# Altium schematic sheet Main.SchDoc
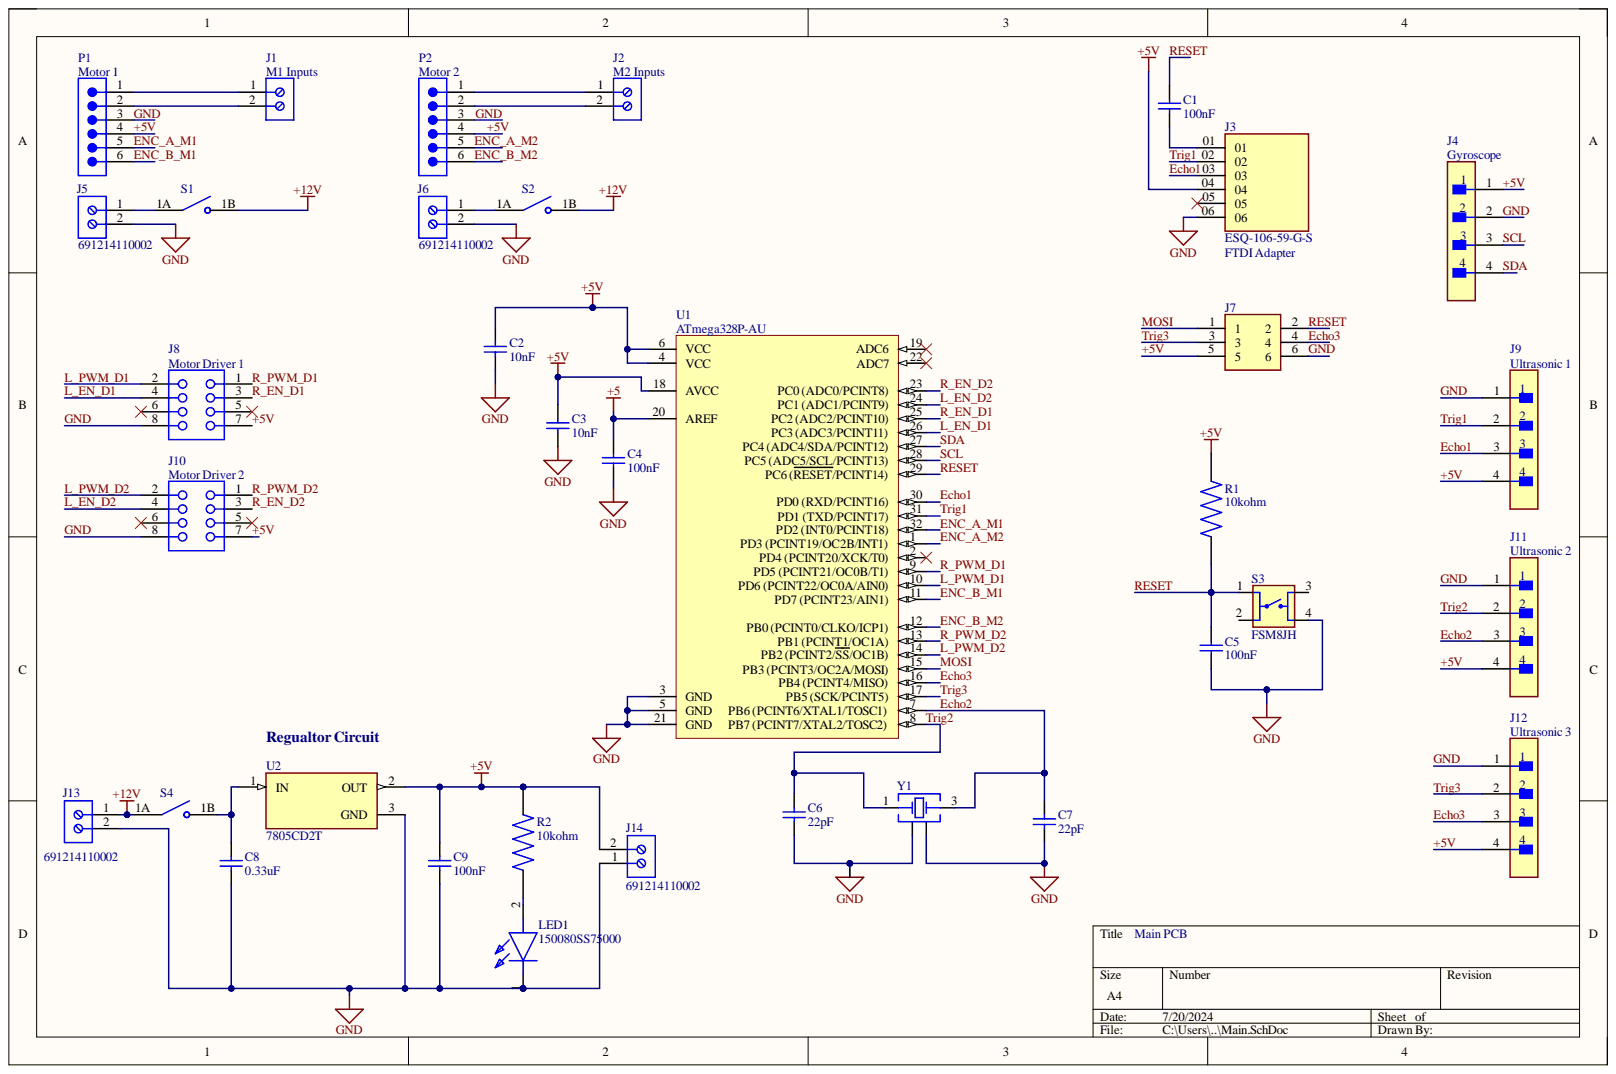
[diagram p0: Main.SchDoc]

## Parts
C1  100nF  [WCAP-CSST_0603_L1.6W0.8H0.8_Reflow]
C2  10nF  [WCAP-CSST_0603_L1.6W0.8H0.8_Reflow]
C3  10nF  [WCAP-CSST_0603_L1.6W0.8H0.8_Reflow]
C4  100nF  [WCAP-CSST_0603_L1.6W0.8H0.8_Reflow]
C5  100nF  [WCAP-CSST_0603_L1.6W0.8H0.8_Reflow]
C6  22pF  [WCAP-CSGP_0603_L1.6W0.8H0.8_Reflow]
C7  22pF  [WCAP-CSGP_0603_L1.6W0.8H0.8_Reflow]
C8  Capacitance: 0.33uF  [WCAP-CSST_0603_L1.6W0.8H0.8_Reflow]  (hidden comment: 100nF)
C9  100nF  [WCAP-CSST_0603_L1.6W0.8H0.8_Reflow]
J1  691214110002  [691214110002]
J2  691214110002  [691214110002]
J3  ESQ-106-59-G-S  [SAMTEC_ESQ-106-59-G-S]
J4  MPU6050  [FP-M20-9990445-MFG]  (value not drawn)
J5  691214110002  [691214110002]
J6  691214110002  [691214110002]
J7  20021111-00006T4LF  [2002111100006T4LF]  (value not drawn)
J8  Motor Driver 1  [SMTC-SSW-104-02-X-D]
J9  Ultrasonic 1  [FP-B4B-XH-A_LF_SN-MFG]
J10  Motor Driver 2  [SMTC-SSW-104-02-X-D]
J11  Ultrasonic 2  [FP-B4B-XH-A_LF_SN-MFG]
J12  Ultrasonic 3  [FP-B4B-XH-A_LF_SN-MFG]
J13  691214110002  [691214110002]
J14  691214110002  [691214110002]
LED1  150080SS75000  [150080SS75000]
P1  Motor 1  [61300611121]
P2  Motor 2  [61300611121]
R1  value 10kohm  [RESC2012X65N]  (hidden comment: RMCF0805FG10K0)
R2  value 10kohm  [RESC2012X65N]  (hidden comment: RMCF0805FG10K0)
S1  DS01-254-L-01BE  [SW_DS01-254-L-01BE]  (value not drawn)
S2  DS01-254-L-01BE  [SW_DS01-254-L-01BE]  (value not drawn)
S3  FSM8JH  [SW_FSM8JH]
S4  DS01-254-L-01BE  [SW_DS01-254-L-01BE]  (value not drawn)
U1  ATmega328P-AU  [32A_M]
U2  7805CD2T  [TO254P1542X460-3N]
Y1  830072604  [WE-XTAL_CFPX-180]  (value not drawn)

## Nets
+5: C4.2 U1.20(AREF)
+12V: J13.1 S1.1B S2.1B S4.1A
+5V: C2.2 C3.2 C9.2 J3.04 J4.1 J7.5 J8.7 J9.4 J10.7 J11.4 J12.4 J14.2 P1.4 P2.4 R1.2 R2.2 U1.4(VCC) U1.6(VCC) U1.18(AVCC) U2.2
Echo1: J3.03 J9.3 U1.30(PD0_(RXD/PCINT16))
Echo2: C7.2 J11.3 U1.7 Y1.3
Echo3: J7.4 J12.3 U1.16(PB4_(PCINT4/MISO))
ENC_A_M1: P1.5 U1.32(PD2_(INT0/PCINT18))
ENC_A_M2: P2.5 U1.1(PD3_(PCINT19/OC2B/INT1))
ENC_B_M1: P1.6 U1.11(PD7_(PCINT23/AIN1))
ENC_B_M2: P2.6 U1.12(PB0_(PCINT0/CLKO/ICP1))
GND: C2.1 C3.1 C4.1 C5.1 C6.1 C7.1 C8.1 C9.1 J3.06 J4.2 J5.2 J6.2 J7.6 J8.8 J9.1 J10.8 J11.1 J12.1 J13.2 J14.1 LED1.1 P1.3 P2.3 S3.4 U1.3(GND) U1.5(GND) U1.21(GND) U2.3 Y1.2 Y1.4
L_EN_D1: J8.4 U1.26(PC3_(ADC3/PCINT11))
L_EN_D2: J10.4 U1.24(PC1_(ADC1/PCINT9))
L_PWM_D1: J8.2 U1.10(PD6_(PCINT22/OC0A/AIN0))
L_PWM_D2: J10.2 U1.14(PB2_(PCINT2/SS/OC1B))
MOSI: J7.1 U1.15(PB3_(PCINT3/OC2A/MOSI))
R_EN_D1: J8.3 U1.25
R_EN_D2: J10.3 U1.23(PC0_(ADC0/PCINT8))
R_PWM_D1: J8.1 U1.9(PD5_(PCINT21/OC0B/T1))
R_PWM_D2: J10.1 U1.13(PB1_(PCINT1/OC1A))
RESET: C1.2 C5.2 J7.2 R1.1 S3.1 U1.29(PC6_(RESET/PCINT14))
SCL: J4.3 U1.28(PC5_(ADC5/SCL/PCINT13))
SDA: J4.4 U1.27(PC4_(ADC4/SDA/PCINT12))
Trig1: J3.02 J9.2 U1.31(PD1_(TXD/PCINT17))
Trig2: C6.2 J11.2 U1.8 Y1.1
Trig3: J7.3 J12.2 U1.17(PB5_(SCK/PCINT5))
NetC1_1: C1.1 J3.01
NetC8_2: C8.2 S4.1B U2.1
NetJ1_1: J1.1 P1.1
NetJ1_2: J1.2 P1.2
NetJ2_1: J2.1 P2.1
NetJ2_2: J2.2 P2.2
NetJ5_1: J5.1 S1.1A
NetJ6_1: J6.1 S2.1A
NetLED1_2: LED1.2 R2.1

Not connected: J3 05; J8 5 6; J10 5 6; S3 2 3; U1 2(PD4_(PCINT20/XCK/T0)) 19(ADC6) 22(ADC7)

## Library data of the parts
C1 C4 C5 C8 C9 = 885382206004: WCAP-CSST Multilayer Ceramic Chip Capacitor, Soft Termination, size 0603, X7R Class II, 100nF, 50VDC; Manufacturer: Wurth Elektronik; Capacitance Tolerance: +/-10%; Case/Size Code: 0603; Dissipation Factor: 3%; Insulation Resistance: 5GOhm; Rated Voltage (DC): 50V; Technical Reference: X7R0603104K050DFCT1S000; Category: Multilayer Ceramic Chip Capacitors; Ceramic Type: X7R Class II; Component Kind: Standard; ComponentLink1Description: Website Link; ComponentLink1URL: https://www.we-online.com/redexpert/article/885382206004?ad; ComponentLink2Description: Datasheet Link; ComponentLink2URL: https://www.we-online.com/redexpert/spec/885382206004?ad; Height: 0.8mm; Length: 1.6mm; Library Name: Altium_WCAP-CSST_rev24a.IntLib; Manufacturer Part Number: 885382206004; Match Code: WCAP-CSST; Mount: Surface Mount; Operating Temperature Max: 125°C; Operating Temperature Min: -55°C; Packaging: Tape and Reel; Simulation: 0603_885382206004_100nF; Width: 0.8mm
  C1 C4 C5 C9: Capacitance: 100nF
C2 C3 = 885382206002: WCAP-CSST Multilayer Ceramic Chip Capacitor, Soft Termination, size 0603, X7R Class II, 10nF, 50VDC; Manufacturer: Wurth Elektronik; Capacitance Tolerance: +/-10%; Case/Size Code: 0603; Dissipation Factor: 2.5%; Insulation Resistance: 10GOhm; Rated Voltage (DC): 50V; Technical Reference: X7R0603103K050DFCT1S000; Capacitance: 10nF; Category: Multilayer Ceramic Chip Capacitors; Ceramic Type: X7R Class II; Component Kind: Standard; ComponentLink1Description: Website Link; ComponentLink1URL: https://www.we-online.com/redexpert/article/885382206002?ad; ComponentLink2Description: Datasheet Link; ComponentLink2URL: https://www.we-online.com/redexpert/spec/885382206002?ad; Height: 0.8mm; Length: 1.6mm; Library Name: Altium_WCAP-CSST_rev24a.IntLib; Manufacturer Part Number: 885382206002; Match Code: WCAP-CSST; Mount: Surface Mount; Operating Temperature Max: 125°C; Operating Temperature Min: -55°C; Packaging: Tape and Reel; Simulation: 0603_885382206002_10nF; Width: 0.8mm
C6 C7 = 885012006004: WCAP-CSGP Multilayer Ceramic Chip Capacitor, General Purpose, size 0603, NP0, 22pF, 10VDC; Manufacturer: Wurth Elektronik; Capacitance Tolerance: +/-5%; Case/Size Code: 0603; Insulation Resistance: 10GOhm; Q Factor: 840; Rated Voltage (DC): 10V; Technical Reference: NP00603220J010DFCT10000; Capacitance: 22pF; Category: Multilayer Ceramic Chip Capacitors; Ceramic Type: NP0; Component Kind: Standard; ComponentLink1Description: Website Link; ComponentLink1URL: https://www.we-online.com/redexpert/article/885012006004?ad; ComponentLink2Description: Datasheet Link; ComponentLink2URL: https://www.we-online.com/redexpert/spec/885012006004?ad; Height: 0.8mm; Length: 1.6mm; Library Name: Altium_WCAP-CSGP_rev24b.IntLib; Manufacturer Part Number: 885012006004; Match Code: WCAP-CSGP; Mount: Surface Mount; Operating Temperature Max: 125°C; Operating Temperature Min: -55°C; Packaging: Tape and Reel; Simulation: 0603_885012006004_22pF; Width: 0.8mm
J1 J2 J5 J6 J13 J14 = CMP-1502-02464-2: NexarPartId: 18818288; Current: 10A; Number of Contacts: 2; Number of Levels: 1; Pitch: 3.5052mm; Plating: Brass, Steel; Stripping Length: -6mm; Voltage: 300V; Wire Gauge (Max): 24AWG; Wire Gauge (Min): 16AWG; Color: Blue, Green; Component Kind: Standard; Contact Material: Brass; Contact Plating: Brass, Tin; Current Rating: 10A; Height: 8.7mm; Housing Material: Nylon; Lead Pitch: 3.5mm; Length: 7.4mm; Library Name: Altium Content Vault; Max Operating Temperature: 105°C; Min Operating Temperature: -40°C; Mount: Through Hole; Number of Pins: 2; Number of Positions Per Level: 2; Number of Rows: 1; Packaging: Box; REACH SVHC: Unknown; RoHS: Compliant; Termination: Screw; Voltage Rating: 300V; Width: 7.9mm; Wire/Cable Gauge: 24AWG
J4 = CMP-00671-05399696-1: M20-9990445 Conn Unshrouded Header HDR 4 POS 2.54mm Solder ST Thru-Hole Bulk/Loose; Connector Type: Male Pin; Contact Resistance: 25mΩ; Current Rating: 3A; Gender: Male; Insulation Resistance: 10GΩ; Number of Contacts: 4; Voltage Rating (AC): 750V; Case/Package: SIL; Color: Black; Component Kind: Standard; Contact Material: Brass, Bronze, Gold, Nickel; Contact Mating Length: 6.096mm; Contact Plating: Gold; Depth: 2.54mm; Height: 2.54mm; Housing Color: Black; Housing Material: Nylon; Lead Pitch: 2.54mm; Length: 10.16mm; Library Name: Altium Content Vault; Max Current Rating: 3A; Max Operating Temperature: 105°C; Min Operating Temperature: -40°C; Mount: Through Hole; NexarPartId: 5399696; Number of Pins: 4; Number of Positions: 4; Number of Rows: 1; Orientation: Straight; Pitch: 2.54mm; Radiation Hardening: No; REACH SVHC: No SVHC; RoHS: Compliant; Termination: Solder; Voltage Rating (DC): 750V; Weight: 45.359237g; Width: 12.7mm
J7 = 20021111-00006T4LF: Connector; Manufacturer_Name: Amphenol Communications Solutions; Arrow Part Number: 20021111-00006T4LF; Arrow Price/Stock: https://www.arrow.com/en/products/20021111-00006t4lf/amphenol-fci?region=europe; Component Kind: Standard; Datasheet Link: https://cdn.amphenol-cs.com/media/wysiwyg/files/drawing/20021111.pdf; Height: 5mm; Manufacturer_Part_Number: 20021111-00006T4LF
J8 J10 = CMP-1023-00343-1: SQ Post Socket, Through-hole, Vertical, -55 to 125 degC, 2.54mm Pitch, 8-Pin, Female, RoHS; Manufacturer: Samtec; PartNumber: SSW-104-02-G-D; Component Kind: Standard; ComponentLink1Description: Manufacturer URL; ComponentLink1URL: http://www.samtec.com; ComponentLink2Description: Datasheet; ComponentLink2URL: http://www.samtec.com/documents/webfiles/pdf/SSW_TH.PDF; ComponentLink3Description: Package Specification; ComponentLink3URL: http://www.samtec.com/documents/webfiles/cpdf/SSW-1XX-XX-XXX-X-XX-XXX-XX-MKT.pdf; DatasheetVersion: F-214; Library Name: Altium Content Vault; Mounting Technology: Through-hole; Orientation: Vertical; PackageDescription: Assembly, Socket Strip .016 X .031 Tail; PackageReference: SSW-104-02-X-D; PackageVersion: CA, 6/1987; Pitch: 2.54 mm; RoHS: True; Temperature Range: -55 to 125 degC
J9 J11 J12 = CMP-17439-000250-1: CONN HEADER VERT 4POS 2.5MM; Contact Resistance: 20mR; Current Rating: 3A; Gender: Male; Insulation Resistance: 1GR; Mated Stacking Heights: 9.8mm; Max Voltage Rating (AC): 250V; Number of Contacts: 4; Wire/Cable Gauge: 22AWG; Color: Natural; Component Kind: Standard; Connector Type: Header; Contact Finish - Mating: TIN; Contact Material: Brass; Contact Plating: Tin; Contact Shape: Square; Contact Type: Male Pin; Depth: 5.75mm; Fastening Type: Detent; Flammability Rating: UL94 V-0; Housing Color: White; Housing Material: Polyamide; Insulation Color: Natural; Insulation Material: Nylon; Lead Free: Lead Free; Lead Pitch: 2.5mm; Length: 12.4mm; Library Name: Altium Content Vault; Material: Polyamide; Max Current Rating: 3A; Max Operating Temperature: 85°C; Max Voltage Rating (DC): 250V; Min Operating Temperature: -25°C; Mount: Through Hole; Number of Positions: 4; Number of Rows: 1; Orientation: Straight; Package Quantity: 500; Packaging: Bulk; Pitch: 2.5mm; REACH SVHC: No SVHC; Series: XH; Termination: Solder; Voltage Rating: 250V; Voltage Rating (AC): 250V
LED1 = 150080SS75000: LED; Manufacturer_Name: Wurth Elektronik; Component Kind: Standard; Datasheet Link: https://www.we-online.com/components/products/datasheet/150080SS75000.pdf; Height: 0.8mm; Manufacturer_Part_Number: 150080SS75000; Mouser Part Number: 710-150080SS75000; Mouser Price/Stock: https://www.mouser.co.uk/ProductDetail/Wurth-Elektronik/150080SS75000?qs=LlUlMxKIyB2zzpiaOzrUdw%3D%3D
P1 P2 = CMP-1502-01070-3: NexarPartId: 20084590; Connector Type: Male Pin; Contact Resistance: 20mΩ; Current Rating: 3A; Gender: Male; Number of Contacts: 6; Operating Supply Voltage: 250V; Pitch: 2.54mm; Color: Black; Component Kind: Standard; Contact Gender: Male; Contact Material: Copper; Contact Mating Length: 5.9944mm; Contact Plating: Gold; Height: 8.89mm; Housing Material: PBT; Lead Free: Lead Free; Lead Pitch: 2.54mm; Library Name: Altium Content Vault; Max Operating Temperature: 105°C; Min Operating Temperature: -40°C; Mount: Through Hole; Number of Pins: 6; Number of Positions: 6; Number of Rows: 1; Orientation: Vertical; Packaging: Bulk; REACH SVHC: Unknown; RoHS: Compliant; Termination: Through Hole; Voltage Rating: 250V
S1 S2 S4 = DS01-254-L-01BE: 1 Position, Through Hole, 2.54 mm Pitch, Raised Slide Actuator, Flat Bottom, Long Pin, DIP Switch; MF: CUI Devices; Availability: In Stock; Check_prices: https://www.snapeda.com/parts/DS01-254-L-01BE/CUI+Devices/view-part/?ref=eda; Component Kind: Standard; CUI_purchase_URL: https://www.cuidevices.com/product/switches/dip-switches/ds01-254-series?utm_source=snapeda.com&utm_medium=referral&utm_campaign=snapedaBOM; Library Name: DS01-254-L-01BE.IntLib; MP: DS01-254-L-01BE; Package: None; Price: None; Purchase-URL: https://pricing.snapeda.com/search/part/DS01-254-L-01BE/?ref=eda; SnapEDA_Link: https://www.snapeda.com/parts/DS01-254-L-01BE/CUI+Devices/view-part/?ref=snap
S3 = FSM8JH: Tactile Switch SPST-NO Top Actuated Through Hole; MF: TE Connectivity; Availability: In Stock; Check_prices: https://www.snapeda.com/parts/FSM8JH/TE+Connectivity+ALCOSWITCH+Switches/view-part/?ref=eda; Component Kind: Standard; Library Name: FSM8JH.IntLib; MP: FSM8JH; Package: None; Price: None; SnapEDA_Link: https://www.snapeda.com/parts/FSM8JH/TE+Connectivity+ALCOSWITCH+Switches/view-part/?ref=snap
U1 = CMP-0095-00269-2: 8-bit AVR Microcontroller, 32KB Flash, 1KB EEPROM, 2KB SRAM, 32-pin TQFP, Industrial Grade (-40°C to 85°C); Code_JEDEC: MS-026-ABA; Component Kind: Standard; ComponentLink1Description: Manufacturer URL; ComponentLink1URL: http://www.atmel.com/; ComponentLink2Description: ATmega48A/48PA/88A/88PA/168A/168PA/328/328P Datasheet; ComponentLink2URL: http://www.atmel.com/dyn/resources/prod_documents/doc8271.pdf; ComponentLink3Description: ATmega48A/48PA/88A/88PA/168A/168PA/328/328P Summary; ComponentLink3URL: http://www.atmel.com/dyn/resources/prod_documents/8271S.pdf; DatasheetVersion: revD, May-2011; Library Name: Altium Content Vault; PackageDescription: 32-Lead, 7x7x1.0 mm Body, 0.8mm Lead Pitch, Thin Profile Plastic Quad Flat Package (TQFP); PackageReference: 32A; PackageVersion: revC, Oct-2010; RevisionNote: DatasheetVersion & PackageVersion updated. URLs added.
U2 = 7805CD2T: Linear Voltage Regulator IC Positive Fixed 1 Output 1.5A D2PAK; MF: STMicroelectronics; Check_prices: https://www.snapeda.com/parts/7805CD2T/STMicroelectronics/view-part/?ref=eda; Component Kind: Standard; Library Name: 7805CD2T.IntLib; MP: 7805CD2T; Package: D2PAK STMicroelectronics; SnapEDA_Link: https://www.snapeda.com/parts/7805CD2T/STMicroelectronics/view-part/?ref=snap
Y1 = CMP-03913-116876517-1: 830072604 WE-XTAL Quartz Crystal; Frequency: 25MHz; Component Kind: Standard; Height: 800µm; Length: 3.2mm; Library Name: Altium Content Vault; Max Operating Temperature: 85°C; Min Operating Temperature: -40°C; NexarPartId: 116876517; Width: 2.5mm
J3 = ESQ-106-59-G-S: Availability: Unavailable; Component Kind: Standard; Library Name: ESQ-106-59-G-S.IntLib; MP: ESQ-106-59-G-S; Package: None; Price: None
R1 R2 = RMCF0805FG10K0: Availability: Unavailable; Component Kind: Standard; Library Name: RMCF0805FG10K0.IntLib; MP: RMCF0805FG10K0; Package: None; Price: None
